# Altium schematic sheet CC2650RC_4MOD1106_keypad_part_rev1_2.SchDoc(CC2650RC_4MOD1106_keypad_part_rev1_2)
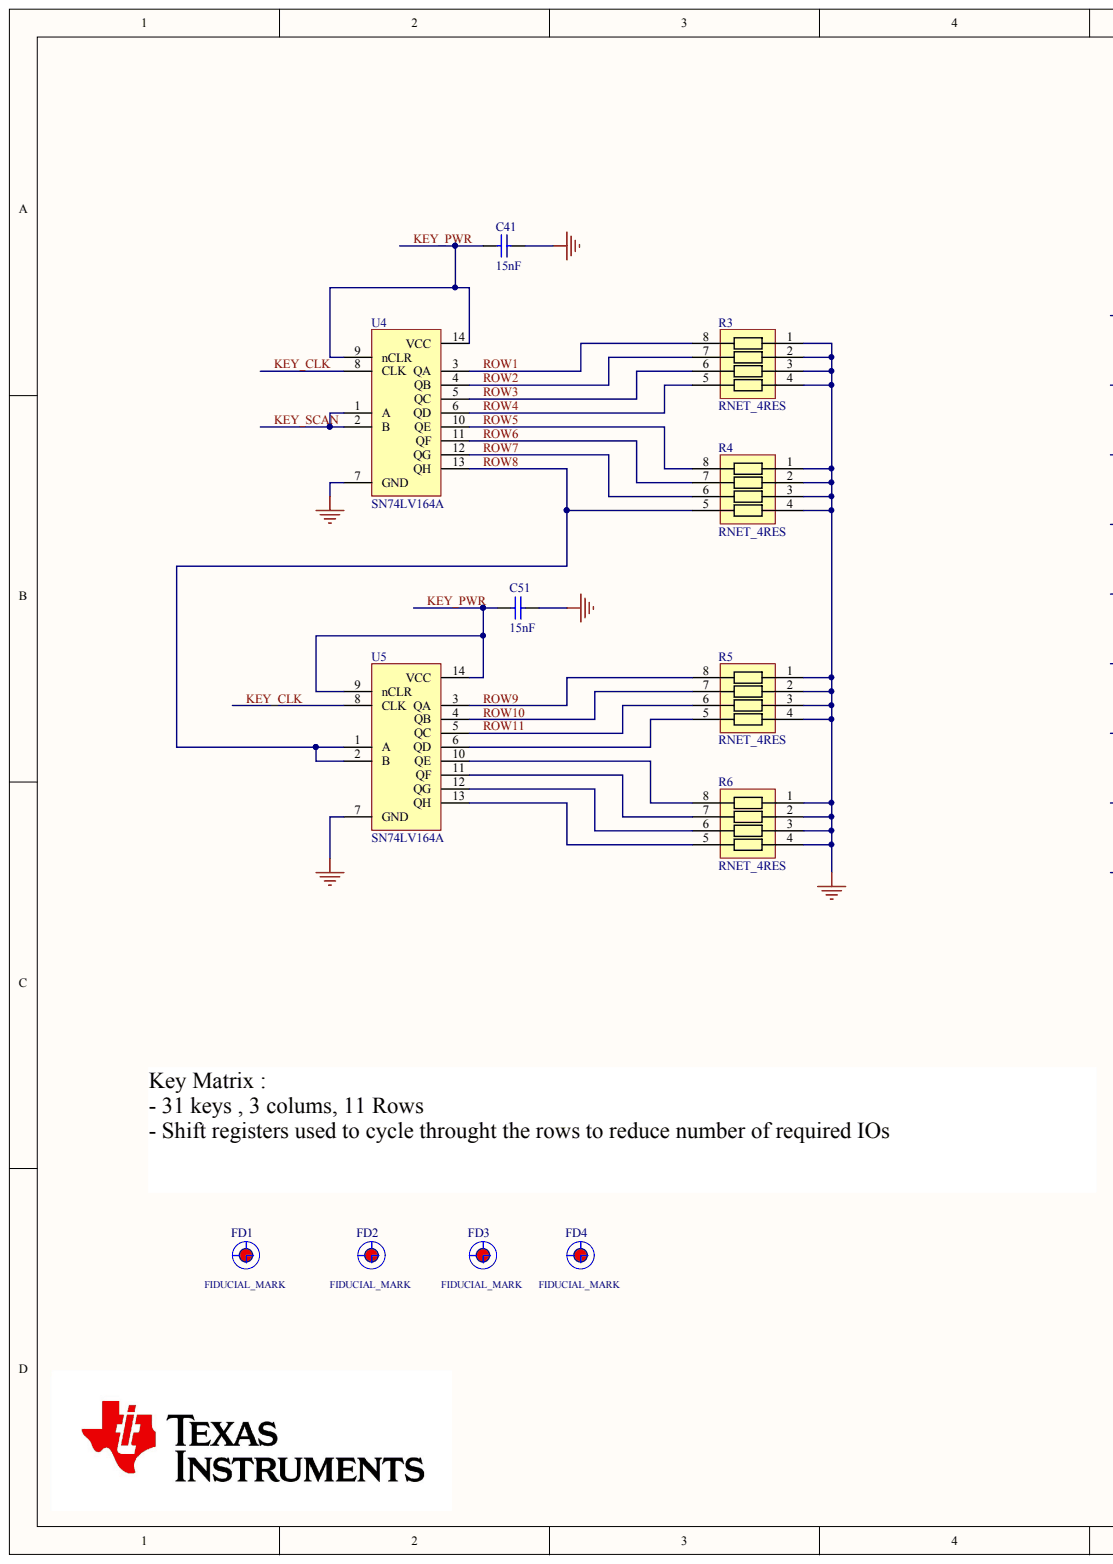
[diagram p0: CC2650RC_4MOD1106_keypad_part_rev1_2.SchDoc, left]
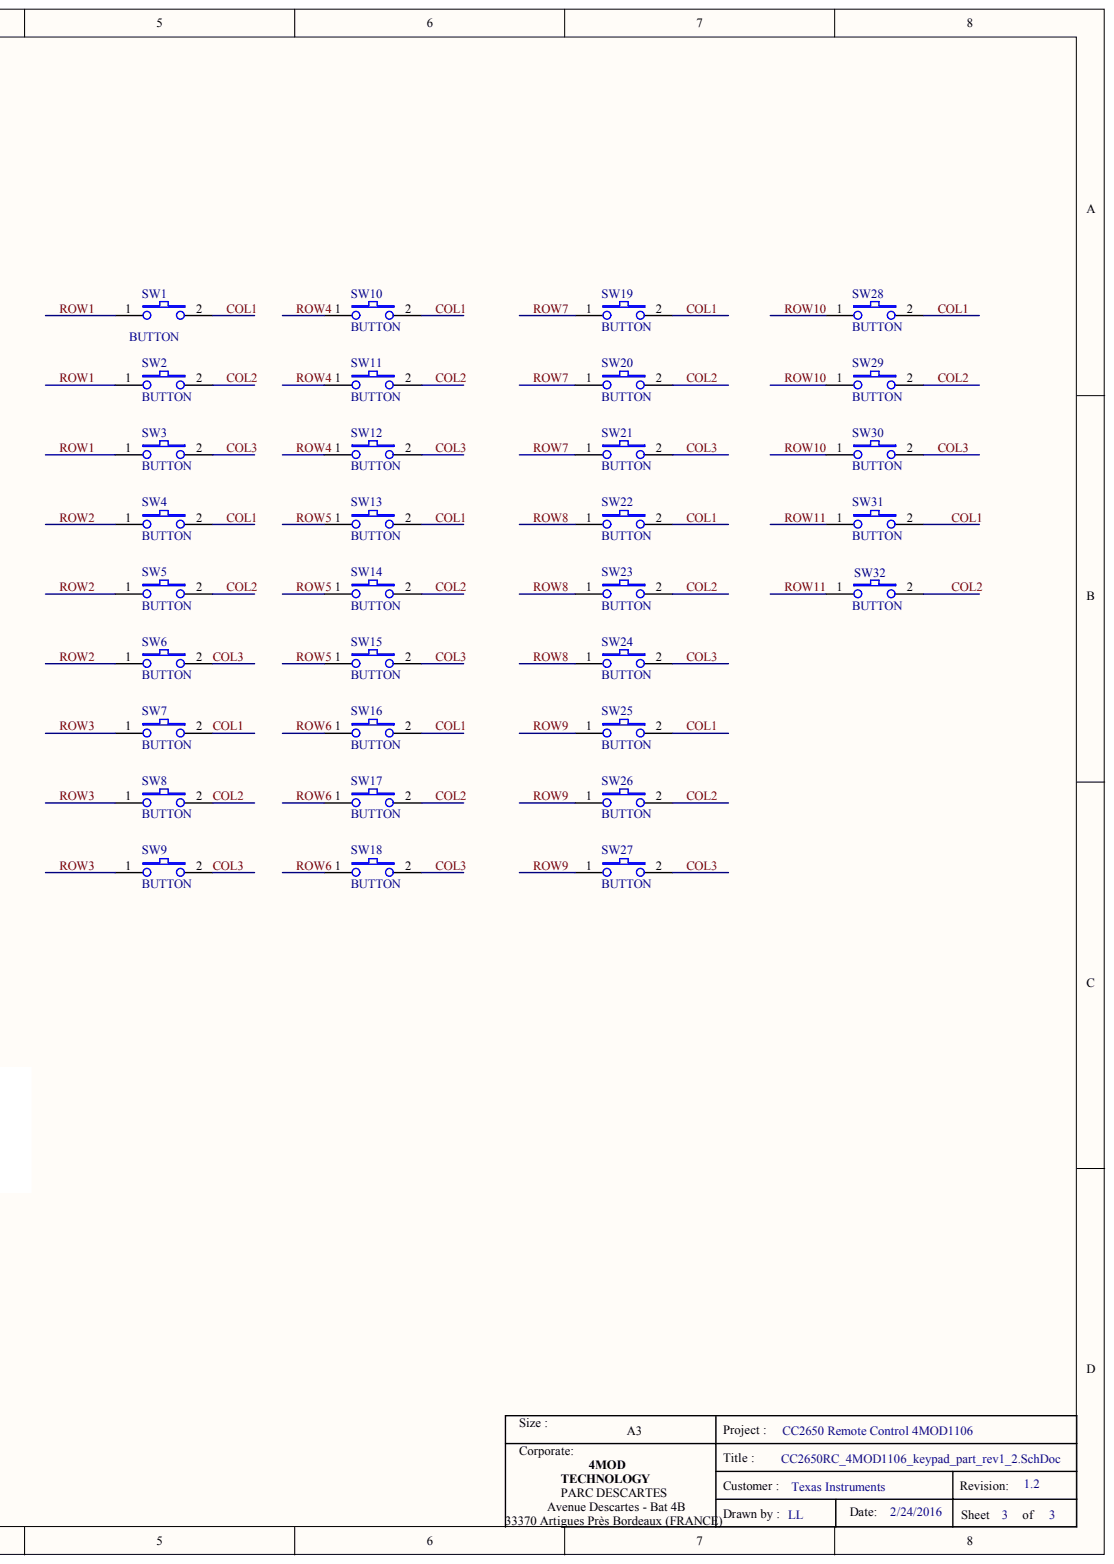
[diagram p1: CC2650RC_4MOD1106_keypad_part_rev1_2.SchDoc, right]

## Parts
C41  value 15nF  [C402_4MOD]
C51  value 15nF  [C402_4MOD]
FD1  FIDUCIAL_MARK  [FIDUCIAL_MARK_2MM]
FD2  FIDUCIAL_MARK  [FIDUCIAL_MARK_2MM]
FD3  FIDUCIAL_MARK  [FIDUCIAL_MARK_2MM]
FD4  FIDUCIAL_MARK  [FIDUCIAL_MARK_2MM]
R3  RNET_4RES  [CHIP_ARRAY_RESISTOR_4RES_0402(EXB-N8V)]
R4  RNET_4RES  [CHIP_ARRAY_RESISTOR_4RES_0402(EXB-N8V)]
R5  RNET_4RES  [CHIP_ARRAY_RESISTOR_4RES_0402(EXB-N8V)]
R6  RNET_4RES  [CHIP_ARRAY_RESISTOR_4RES_0402(EXB-N8V)]
SW1  BUTTON  [DOME2 - cut]
SW2  BUTTON  [DOME2 - cut]
SW3  BUTTON  [DOME2 - cut]
SW4  BUTTON  [DOME2 - cut]
SW5  BUTTON  [DOME2]
SW6  BUTTON  [DOME2 - cut]
SW7  BUTTON  [DOME2 - cut]
SW8  BUTTON  [DOME2]
SW9  BUTTON  [DOME2 - cut]
SW10  BUTTON  [DOME2]
SW11  BUTTON  [DOME2]
SW12  BUTTON  [DOME2 - cut]
SW13  BUTTON  [DOME2 - cut]
SW14  BUTTON  [DOME2]
SW15  BUTTON  [DOME2]
SW16  BUTTON  [DOME2]
SW17  BUTTON  [DOME2]
SW18  BUTTON  [DOME2]
SW19  BUTTON  [DOME2]
SW20  BUTTON  [DOME2]
SW21  BUTTON  [DOME2 - cut]
SW22  BUTTON  [DOME2 - cut]
SW23  BUTTON  [DOME2]
SW24  BUTTON  [DOME2 - cut]
SW25  BUTTON  [DOME2 - cut]
SW26  BUTTON  [DOME2]
SW27  BUTTON  [DOME2 - cut]
SW28  BUTTON  [DOME2 - CUT]
SW29  BUTTON  [DOME2]
SW30  BUTTON  [DOME2 - cut]
SW31  BUTTON  [DOME2 - cut]
SW32  BUTTON  [DOME2]
U4  SN74LV164A  [TSOP14]
U5  SN74LV164A  [TSOP14]

## Nets
GND: C41.1 C51.1 R3.1 R3.2 R3.3 R3.4 R4.1 R4.2 R4.3 R4.4 R5.1 R5.2 R5.3 R5.4 R6.1 R6.2 R6.3 R6.4 U4.7(GND) U5.7(GND)
COL1: SW1.2 SW4.2 SW7.2 SW10.2 SW13.2 SW16.2 SW19.2 SW22.2 SW25.2 SW28.2 SW31.2
COL2: SW2.2 SW5.2 SW8.2 SW11.2 SW14.2 SW17.2 SW20.2 SW23.2 SW26.2 SW29.2 SW32.2
COL3: SW3.2 SW6.2 SW9.2 SW12.2 SW15.2 SW18.2 SW21.2 SW24.2 SW27.2 SW30.2
KEY_CLK: U4.8(CLK) U5.8(CLK)
KEY_PWR: C41.2 C51.2 U4.9(nCLR) U4.14(VCC) U5.9(nCLR) U5.14(VCC)
KEY_SCAN: U4.1(A) U4.2(B)
ROW1: R3.8 SW1.1 SW2.1 SW3.1 U4.3(QA)
ROW2: R3.7 SW4.1 SW5.1 SW6.1 U4.4(QB)
ROW3: R3.6 SW7.1 SW8.1 SW9.1 U4.5(QC)
ROW4: R3.5 SW10.1 SW11.1 SW12.1 U4.6(QD)
ROW5: R4.8 SW13.1 SW14.1 SW15.1 U4.10(QE)
ROW6: R4.7 SW16.1 SW17.1 SW18.1 U4.11(QF)
ROW7: R4.6 SW19.1 SW20.1 SW21.1 U4.12(QG)
ROW8: R4.5 SW22.1 SW23.1 SW24.1 U4.13(QH) U5.1(A) U5.2(B)
ROW9: R5.8 SW25.1 SW26.1 SW27.1 U5.3(QA)
ROW10: R5.7 SW28.1 SW29.1 SW30.1 U5.4(QB)
ROW11: R5.6 SW31.1 SW32.1 U5.5(QC)
NetR5_5: R5.5 U5.6(QD)
NetR6_5: R6.5 U5.13(QH)
NetR6_6: R6.6 U5.12(QG)
NetR6_7: R6.7 U5.11(QF)
NetR6_8: R6.8 U5.10(QE)

## Library data of the parts
C41 C51 = Cap: Capacitor, Ceramic X7R, 15nF, 16V, +/- 5%, SMD  case 0402; Component Kind: Standard; LatestRevisionDate: 17-Jul-2002; LatestRevisionNote: Re-released for DXP Platform.; Library Name: Miscellaneous Devices.IntLib; PackageReference: RAD-0.3; Published: 8-Jun-2000; Signal Integrity: Cap; Simulation: CAP; Publisher: Altium Limited
FD1-FD4 = FIDUCIAL_MARK: FIDUCIAL MARK to pick and place machine; Component Kind: Standard; Library Name: 4MOD_PASSIVE.SCHLIB
R3-R6 = RNET_4RES: Resistor Network, chip array, 4 resistors; Component Kind: Standard; Library Name: 4MOD_PASSIVE.SCHLIB
SW1-SW32 = TOUCHE: Touches tactile h=7mm boîtier=6x6mm, SHURTER; Component Kind: Standard; Library Name: PASSIF.SCHLIB
U4 U5 = SN74LV164A: SN74LV164A ,8-Bit Parallel-Out Serial Shift Registers, smd case TSSOP14; Component Kind: Standard; Library Name: 4MOD_Devices.SCHLIB
